# Altium schematic sheet HS1-JTAG.SchDoc
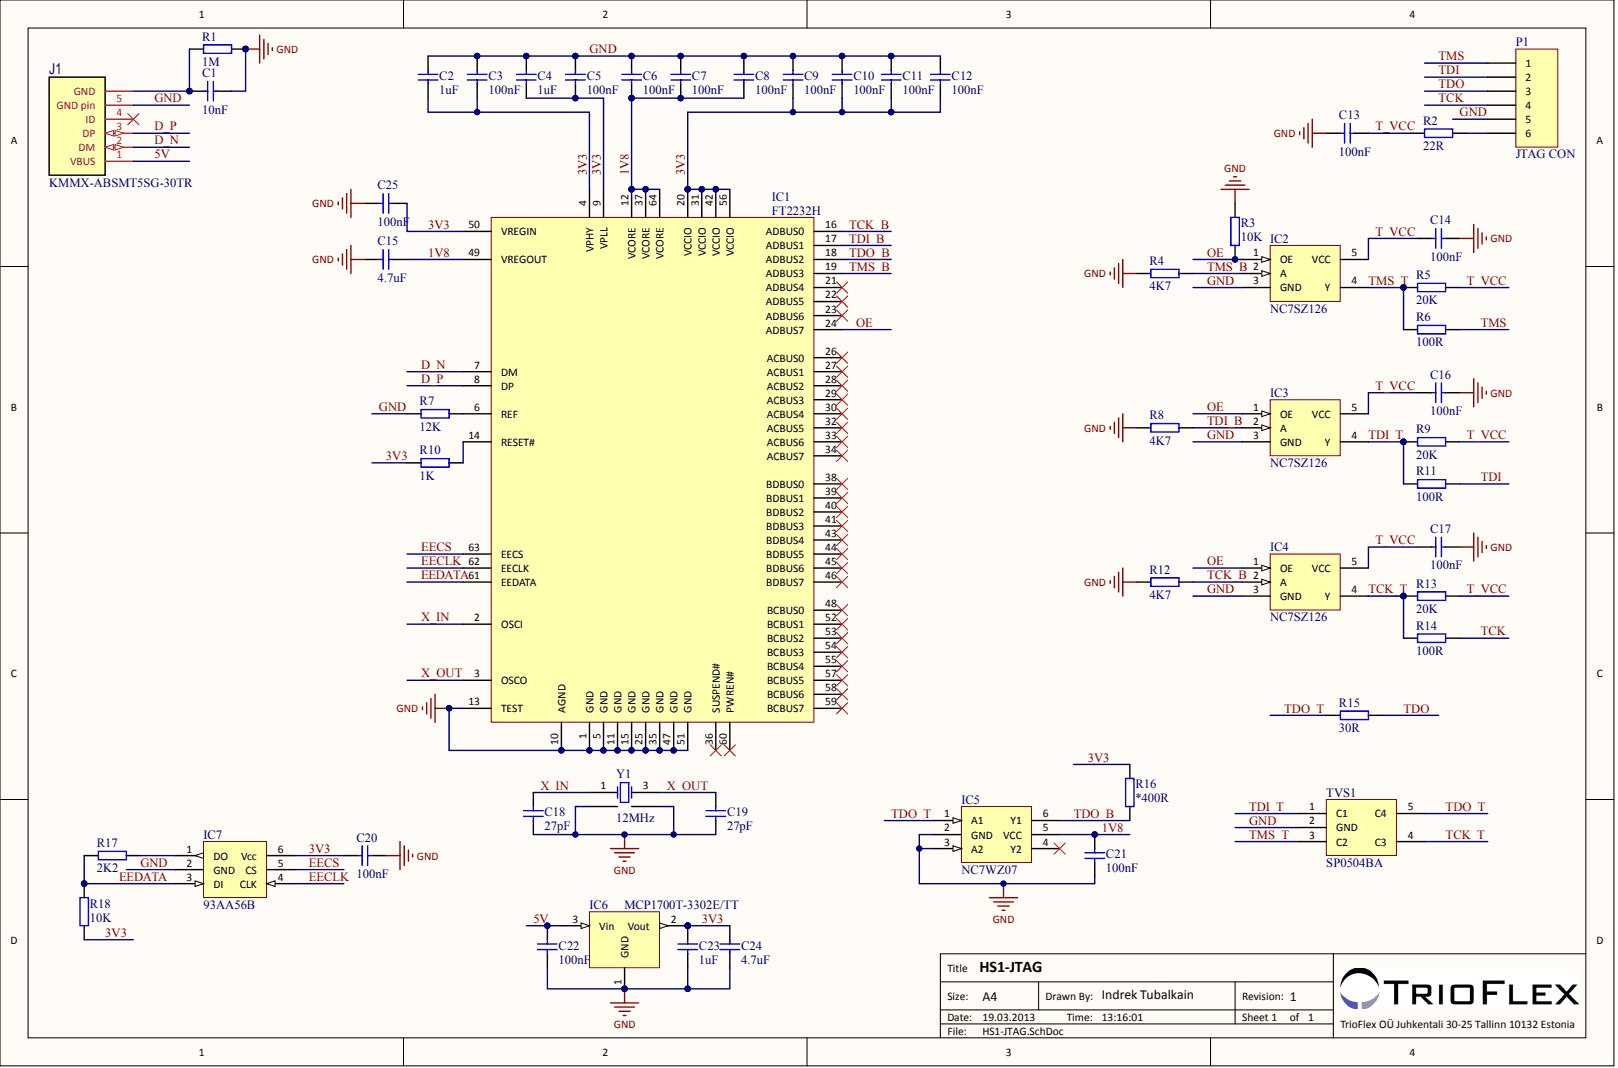
[diagram p0: HS1-JTAG.SchDoc]

## Parts
C1  value 10nF  (hidden comment: CAP-NP)
C2  value 1uF  (hidden comment: 100nF)
C3  100nF
C4  100nF  value 1uF
C5  100nF
C6  100nF
C7  100nF
C8  100nF
C9  100nF
C10  100nF
C11  100nF
C12  100nF
C13  100nF
C14  100nF
C15  4.7uF
C16  100nF
C17  100nF
C18  27pF
C19  27pF
C20  100nF
C21  100nF
C22  value 100nF  (hidden comment: 1uF)
C23  1uF
C24  4.7uF
C25  100nF
IC1  FT2232H
IC2  NC7SZ126
IC3  NC7SZ126
IC4  NC7SZ126
IC5  NC7WZ07
IC6  value MCP1700T-3302E/TT  (hidden comment: VREG-SOT23-3-MC)
IC7  value 93AA56B  (hidden comment: 93AA56A_S_EEPROM)
J1  value KMMX-ABSMT5SG-30TR  (hidden comment: USB_micro_AB)
P1  JTAG CON
R1  value 1M  (hidden comment: RES)
R2  22R
R3  10K
R4  4K7
R5  20K
R6  100R
R7  12K
R8  4K7
R9  20K
R10  1K
R11  100R
R12  4K7
R13  20K
R14  100R
R15  30R
R16  *400R
R17  value 2K2  (hidden comment: RES)
R18  value 10K  (hidden comment: RES)
TVS1  SP0504BA
Y1  value 12MHz  (hidden comment: XTAL-4P)

## Nets
1V8: C6.2 C7.2 C8.2 C15.2 C21.2 IC1.12(VCORE) IC1.37(VCORE) IC1.49(VREGOUT) IC1.64(VCORE) IC5.5(VCC)
3V3: C2.2 C3.2 C4.2 C5.2 C9.2 C10.2 C11.2 C12.2 C20.1 C23.1 C24.1 C25.1 IC1.4(VPHY) IC1.9(VPLL) IC1.20(VCCIO) IC1.31(VCCIO) IC1.42(VCCIO) IC1.50(VREGIN) IC1.56(VCCIO) IC6.2 IC7.6(Vcc) R10.1 R16.2 R18.2
5V: C22.1 IC6.3 J1.1(VBUS)
D_N: IC1.7(DM) J1.2(DM)
D_P: IC1.8(DP) J1.3(DP)
EECLK: IC1.62(EECLK) IC7.4(CLK)
EECS: IC1.63(EECS) IC7.5(CS)
EEDATA: IC1.61(EEDATA) IC7.3(DI) R17.1 R18.1
GND: C1.2 C2.1 C3.1 C4.1 C5.1 C6.1 C7.1 C8.1 C9.1 C10.1 C11.1 C12.1 C13.2 C14.1 C15.1 C16.2 C17.2 C18.1 C19.1 C20.2 C21.1 C22.2 C23.2 C24.2 C25.2 IC1.1(GND) IC1.5(GND) IC1.10(AGND) IC1.11(GND) IC1.13(TEST) IC1.15(GND) IC1.25(GND) IC1.35(GND) IC1.47(GND) IC1.51(GND) IC2.3(GND) IC3.3(GND) IC4.3(GND) IC5.2(GND) IC5.3(A2) IC6.1 IC7.2(GND) J1.5(GND_pin) P1.5 R1.2 R3.1 R4.1 R7.1 R8.1 R12.1 TVS1.2(GND) Y1.2 Y1.4
OE: IC1.24(ADBUS7) IC2.1(OE) IC3.1(OE) IC4.1(OE) R3.2
T_VCC: C13.1 C14.2 C16.1 C17.1 IC2.5(VCC) IC3.5(VCC) IC4.5(VCC) R2.1 R5.1 R9.2 R13.2
TCK: P1.4 R14.2
TCK_B: IC1.16(ADBUS0) IC4.2(A) R12.2
TCK_T: IC4.4(Y) R13.1 R14.1 TVS1.4(C3)
TDI: P1.2 R11.2
TDI_B: IC1.17(ADBUS1) IC3.2(A) R8.2
TDI_T: IC3.4(Y) R9.1 R11.1 TVS1.1(C1)
TDO: P1.3 R15.2
TDO_B: IC1.18(ADBUS2) IC5.6(Y1) R16.1
TDO_T: IC5.1(A1) R15.1 TVS1.5(C4)
TMS: P1.1 R6.2
TMS_B: IC1.19(ADBUS3) IC2.2(A) R4.2
TMS_T: IC2.4(Y) R5.2 R6.1 TVS1.3(C2)
X_IN: C18.2 IC1.2(OSCI) Y1.1
X_OUT: C19.2 IC1.3(OSCO) Y1.3
NetC1_1: C1.1 J1.6(GND) R1.1
NetIC1_6: IC1.6(REF) R7.2
NetIC1_14: IC1.14(RESET#) R10.2
NetIC7_1: IC7.1(DO) R17.2
NetP1_6: P1.6 R2.2

Not connected: IC1 21(ADBUS4) 22(ADBUS5) 23(ADBUS6) 26(ACBUS0) 27(ACBUS1) 28(ACBUS2) 29(ACBUS3) 30(ACBUS4) 32(ACBUS5) 33(ACBUS6) 34(ACBUS7) 36(SUSPEND#) 38(BDBUS0) 39(BDBUS1) 40(BDBUS2) 41(BDBUS3) 43(BDBUS4) 44(BDBUS5) 45(BDBUS6) 46(BDBUS7) 48(BCBUS0) 52(BCBUS1) 53(BCBUS2) 54(BCBUS3) 55(BCBUS4) 57(BCBUS5) 58(BCBUS6) 59(BCBUS7) 60(PWREN#); IC5 4(Y2); J1 4(ID)

## Library data of the parts
C1 = (no symbol name): ID: 4; Component: C1206
C2-C14 C16-C23 C25 = (no symbol name): ID: 1; Component: C0402
  C3 C5-C14 C16 C17 C20 C21 C25: Value: 100nF
  C18 C19: Value: 27pF
  C23: Value: 1uF
C15 C24 = (no symbol name): ID: 2; Component: C0603; Value: 4.7uF
IC1 = (no symbol name): ID: 4; Value: FT2232H; Component: FT2232H
IC2-IC4 = (no symbol name): ID: 5; Value: NC7SZ126; Component: NC7SZ126
IC5 = (no symbol name): ID: 6; Value: NC7WZ07; Component: NC7WZ07
IC6 = (no symbol name): ID: 1; Component: VREG-SOT23-3-MC
IC7 = (no symbol name): ID: 2; Component: MC-SERIAL-EEPROM
J1 = (no symbol name): ID: 32; Component: USB_micro_AB
R1 = (no symbol name): Value: 1M; ID: 4; Component: R1206
R2 R6 R11 R14 R15 = (no symbol name): ID: 2; Component: R0603
  R2: Value: 22R
  R6 R11 R14: Value: 100R
  R15: Value: 30R
R3-R5 R7-R10 R12 R13 R16-R18 = (no symbol name): ID: 1; Component: R0402
  R3: Value: 10K
  R4 R8 R12: Value: 4K7
  R5 R9 R13: Value: 20K
  R7: Value: 12K
  R10: Value: 1K
  R16: Value: *400R
TVS1 Y1 = (no symbol name): ID: 3
  TVS1: Value: SP0504BA; Component: SP0504BA
  Y1: Component: XTAL-4P
P1 = (no symbol name): LatestRevisionDate: 17-Jul-2002; LatestRevisionNote: Re-released for DXP Platform.; Publisher: Altium Limited
